# Altium schematic sheet XS2002.SchDoc(XS2002)
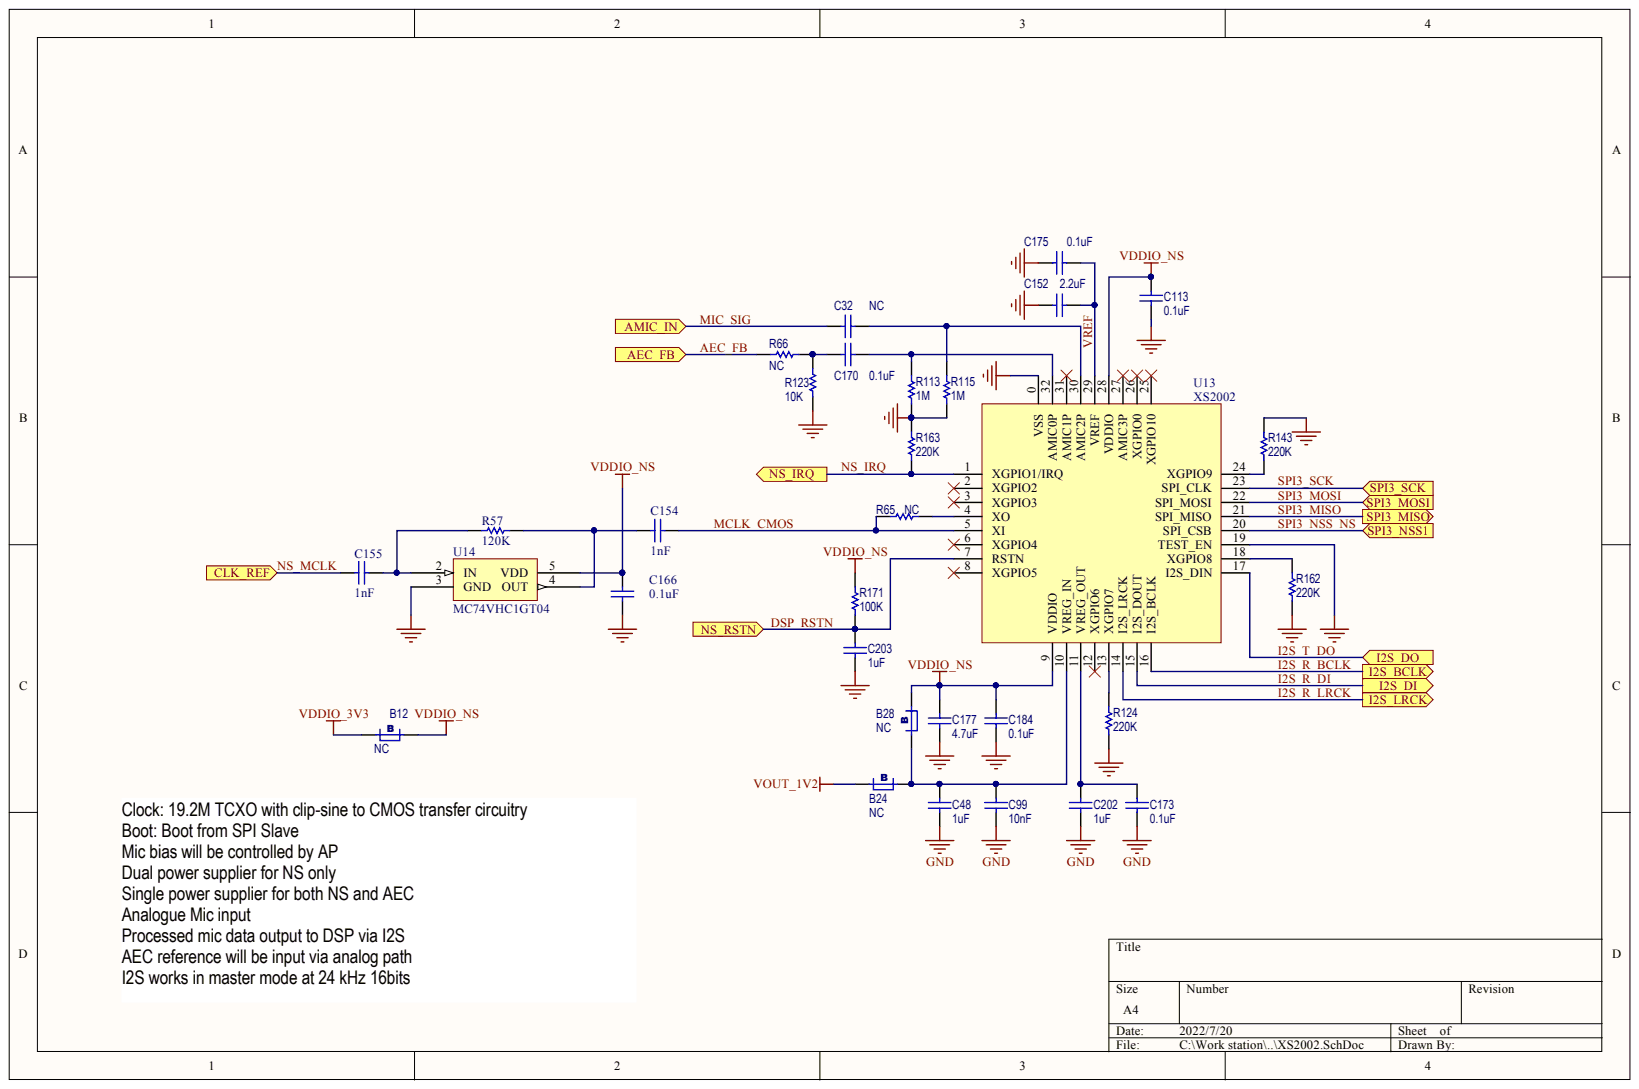
[diagram p0: XS2002.SchDoc]

## Parts
B12  NC  [0402]
B24  NC  [0402]
B28  NC  [0402]
C32  NC  [0402]
C48  1uF  [0402]
C99  10nF  [0402]
C113  0.1uF  [0402]
C152  2.2uF  [0402]
C154  1nF  [0402]
C155  1nF  [0402]
C166  0.1uF  [0402]
C170  0.1uF  [0402]
C173  0.1uF  [0402]
C175  0.1uF  [0402]
C177  4.7uF  [0402]
C184  0.1uF  [0402]
C202  1uF  [0402]
C203  1uF  [0402]
R57  120K  [0402]
R65  NC  [0402]
R66  NC  [0402]
R113  1M  [0402]
R115  1M  [0402]
R123  10K  [0402]
R124  220K  [0402]
R143  220K  [0402]
R162  220K  [0402]
R163  220K  [0402]
R171  100K  [0402]
U13  XS2002  [QFN32_4X4]
U14  MC74VHC1GT04  [SC-88A]

## Ports
AEC_FB, AMIC_IN, CLK_REF, I2S_BCLK, I2S_DI, I2S_DO, I2S_LRCK, NS_IRQ, NS_RSTN, SPI3_MISO, SPI3_MOSI, SPI3_NSS1, SPI3_SCK

## Nets
GND: C48.2 C99.2 C113.2 C152.2 C166.1 C173.2 C175.2 C177.2 C184.2 C202.1 C203.2 R113.2 R115.2 R123.2 R124.2 R143.1 R162.2 R163.1 U13.0(VSS) U13.19(TEST_EN) U14.3(GND)
VDDIO_3V3: B12.1
VDDIO_NS: B12.2 B28.2 C113.1 C166.2 C177.1 C184.1 R171.1 U13.9(VDDIO) U13.28(VDDIO) U14.5(VDD)
VOUT_1V2: B24.1
AEC_FB: R66.1 ; port AEC_FB
DSP_RSTN: C203.1 R171.2 U13.7(RSTN) ; port NS_RSTN
I2S_R_BCLK: U13.16(I2S_BCLK) ; port I2S_BCLK
I2S_R_DI: U13.15(I2S_DOUT) ; port I2S_DI
I2S_R_LRCK: U13.14(I2S_LRCK) ; port I2S_LRCK
I2S_T_DO: U13.17(I2S_DIN) ; port I2S_DO
MCLK_CMOS: C154.1 R65.1 U13.5(XI)
MIC_SIG: C32.1 ; port AMIC_IN
NS_IRQ: R163.2 U13.1(XGPIO1/IRQ) ; port NS_IRQ
NS_MCLK: C155.1 ; port CLK_REF
SPI3_MISO: U13.21(SPI_MISO) ; port SPI3_MISO
SPI3_MOSI: U13.22(SPI_MOSI) ; port SPI3_MOSI
SPI3_NSS_NS: U13.20(SPI_CSB) ; port SPI3_NSS1
SPI3_SCK: U13.23(SPI_CLK) ; port SPI3_SCK
VREF: C152.1 C175.1 U13.29(VREF)
NetB24_2: B24.2 B28.1 C48.1 C99.1 U13.10(VREG_IN)
NetC32_2: C32.2 R115.1 U13.30(AMIC2P)
NetC154_2: C154.2 R57.1 U14.4(OUT)
NetC155_2: C155.2 R57.2 U14.2(IN)
NetC170_1: C170.1 R66.2 R123.1
NetC170_2: C170.2 R113.1 U13.32(AMIC0P)
NetC173_1: C173.1 C202.2 U13.11(VREG_OUT)
NetR65_2: R65.2 U13.4(XO)
NetR124_1: R124.1 U13.13(XGPIO7)
NetR143_2: R143.2 U13.24(XGPIO9)
NetR162_1: R162.1 U13.18(XGPIO8)

Not connected: U13 2(XGPIO2) 3(XGPIO3) 6(XGPIO4) 8(XGPIO5) 12(XGPIO6) 25(XGPIO10) 26(XGPIO0) 27(AMIC3P) 31(AMIC1P)

## Library data of the parts
B12 B24 B28 = B: Component Kind: Standard; Library Name: SCH Lib.SCHLIB; Revision: July-2002: Re-released for DXP Platform.
C32 C48 C99 C152 C177 C202 C203 = Cap Semi: Capacitor (Semiconductor SIM Model); Value: 0.1uF; Component Kind: Standard; Library Name: Miscellaneous Devices.IntLib; Published: 8-Jun-2000; Revision: July-2002: Re-released for DXP Platform.; Signal Integrity: Cap Semi; Simulation: CAP; Publisher: Altium Limited
C113 C170 C173 C175 C184 = Cap Semi: Capacitor (Semiconductor SIM Model); Component Kind: Standard; Library Name: Miscellaneous Devices.IntLib; Published: 8-Jun-2000; Revision: July-2002: Re-released for DXP Platform.; Signal Integrity: Cap Semi; Simulation: CAP; Value: 0.1uF; Publisher: Altium Limited
C154 C155 C166 = Cap: Capacitor; Manufacturer: Generic Components; Class: Passive; Value: 100pF; Component Kind: Standard; Library Name: Miscellaneous Devices.IntLib; Published: 08/06/2000; Signal Integrity: Cap; Simulation: CAP; SubClass: Capacitor; Publisher: Altium Hobart Technology Centre
R57 R65 R66 R113 R115 R123 R124 R143 R162 R163 R171 = Res1: Resistor; Manufacturer: Generic Components; Class: Passive; Value: 1K; Component Kind: Standard; Published: 08/06/2000; Signal Integrity: Res1; Simulation: RESISTOR; SubClass: Resistor; Publisher: Altium Hobart Technology Centre
  R57: Library Name: Miscellaneous Devices.IntLib
  R65 R66 R113 R115 R123 R124 R143 R162 R163 R171: Library Name: RF.SCHLIB
U14 = MC74VHC1GT04: 单非门; Component Kind: Standard; Library Name: SCH Lib.SCHLIB
U13 = XS2002: Component Kind: Standard; Library Name: zySCHLIB_Z.SCHLIB
